# Altium schematic sheet 3.3V Regulator.SchDoc
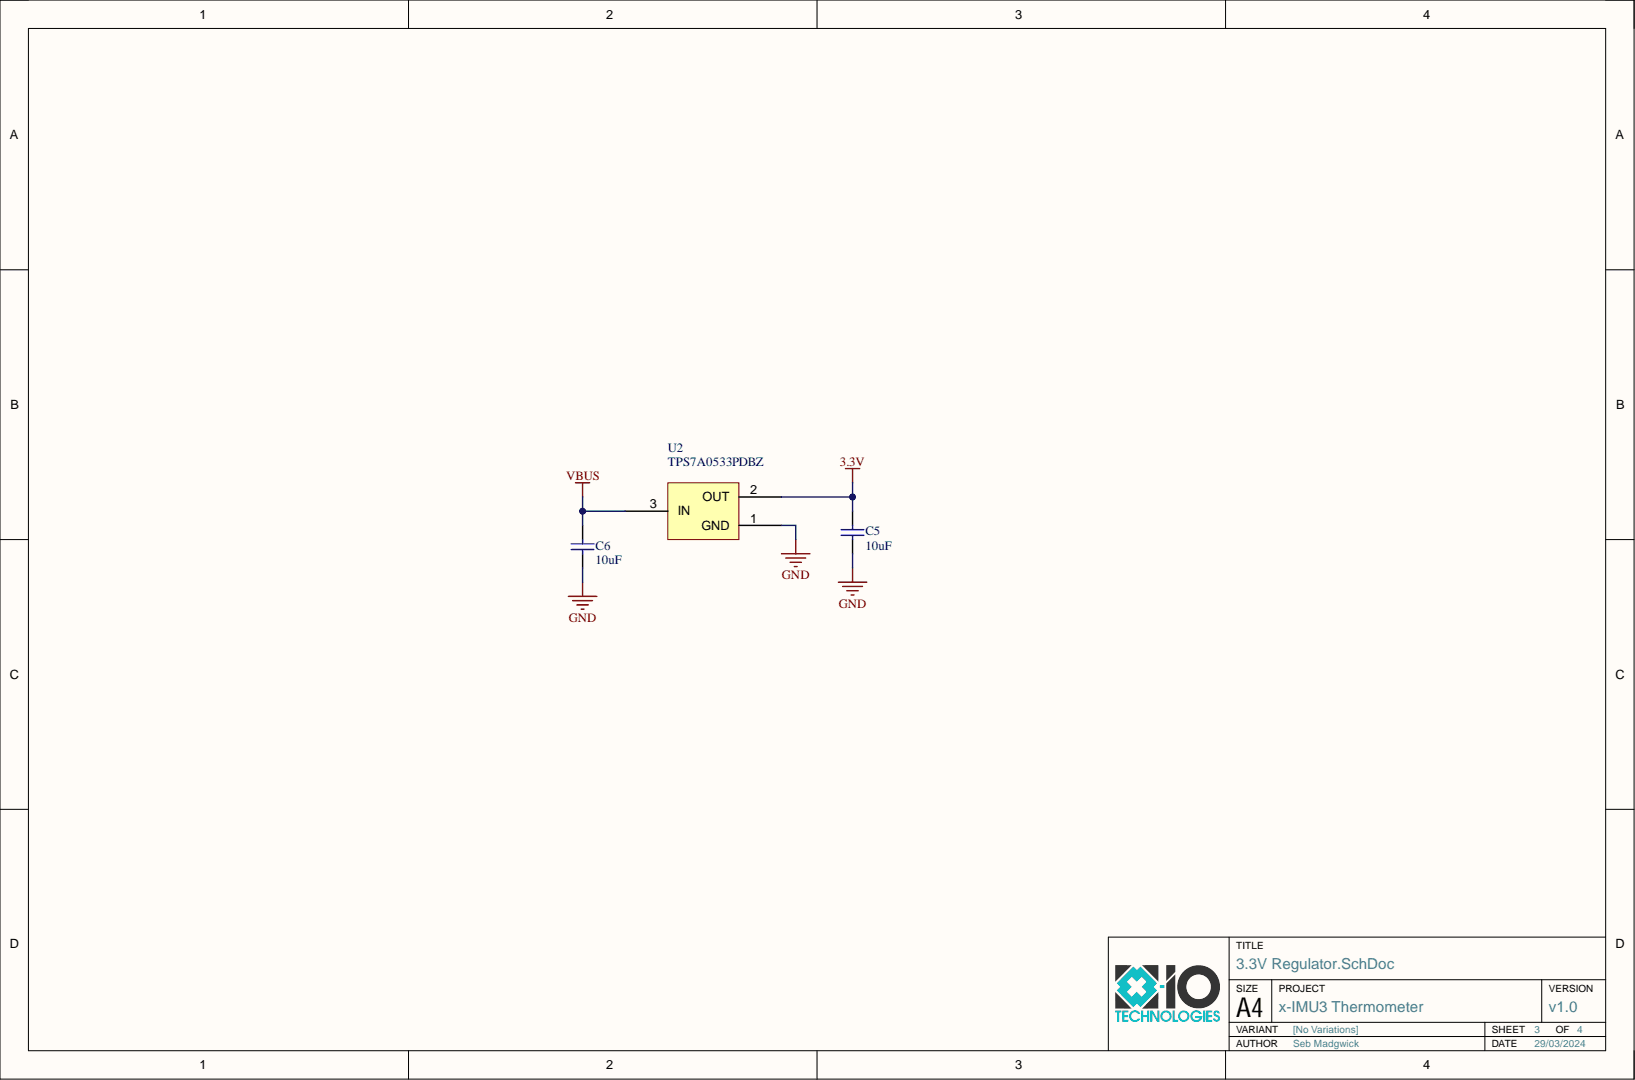
[diagram p0: 3.3V Regulator.SchDoc]

## Parts
C5  10uF  [Capacitor 0402]
C6  10uF  [Capacitor 0402]
U2  TPS7A0533PDBZ  [SOT-23]

## Nets
3.3V: C5.2 U2.2
GND: C5.1 C6.1 U2.1
VBUS: C6.2 U2.3

## Library data of the parts
C5 C6 = Capacitor: Component Kind: Standard; Library Name: x-io.SchLib
U2 = TPS7A0533PDBZ: Component Kind: Standard; Library Name: x-io.SchLib
